# Altium schematic sheet Sheet1.SchDoc
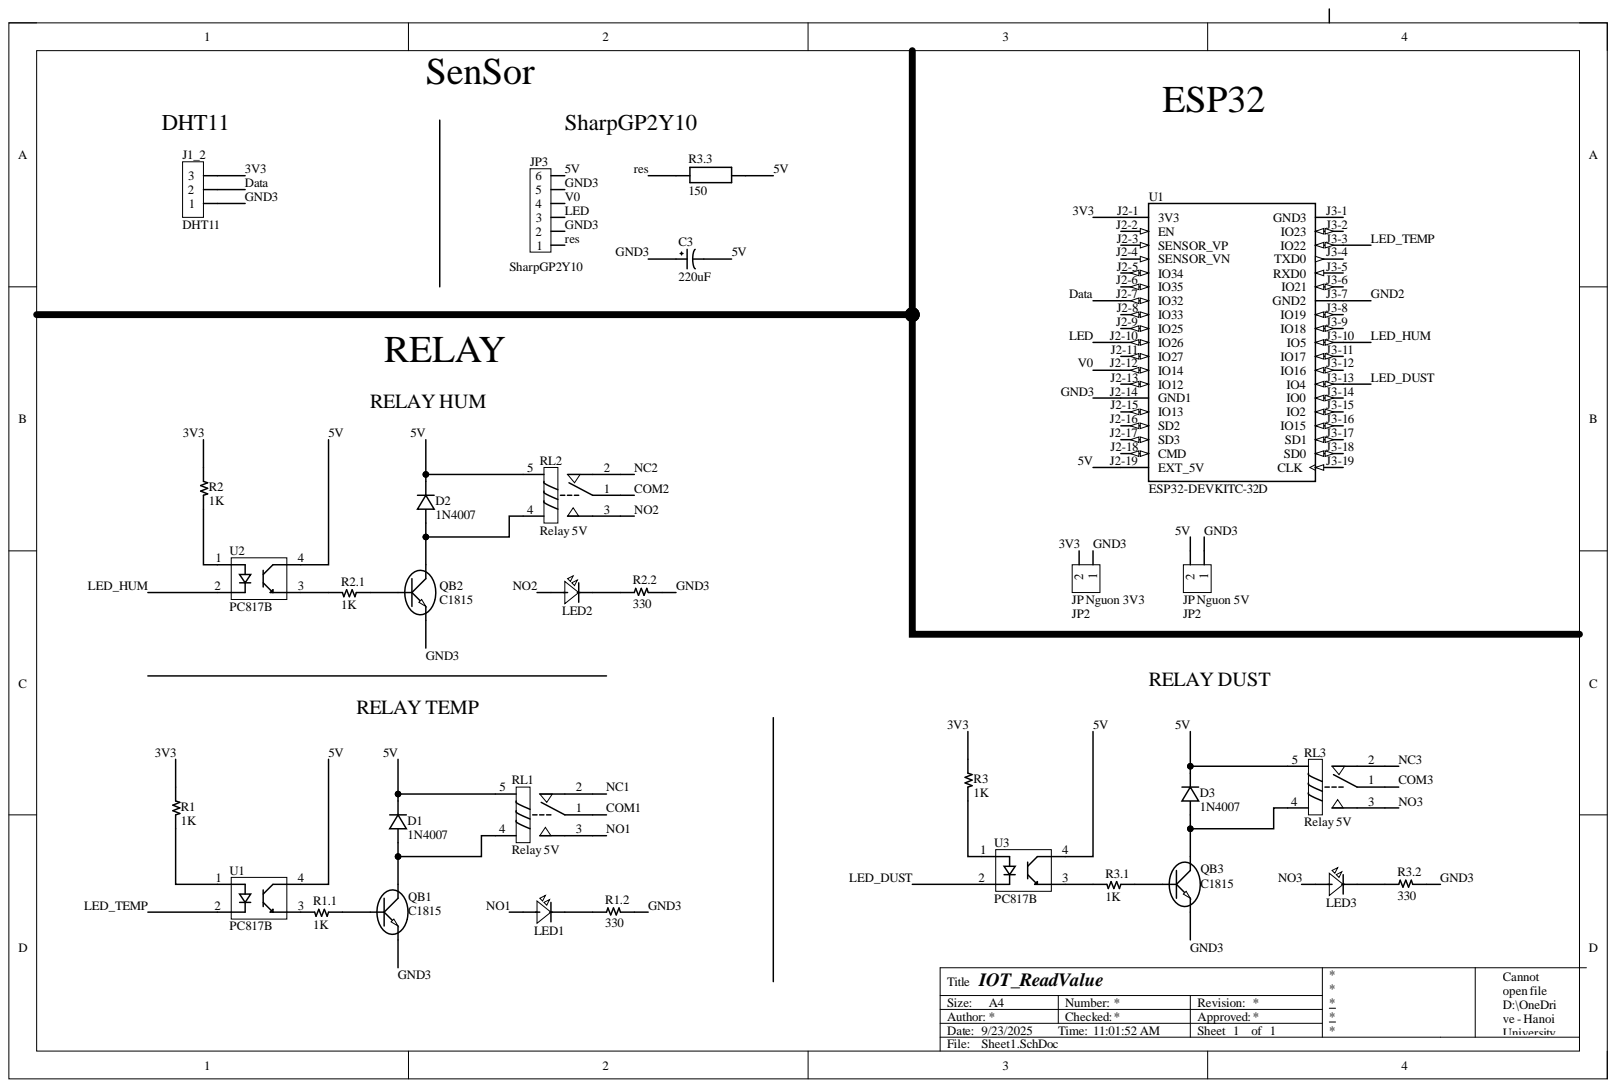
[diagram p0: Sheet1.SchDoc]

## Parts
C3  220uF  [CP1/2]
D1  1N4007  [D0.5A]
D2  1N4007  [D0.5A]
D3  1N4007  [D0.5A]
J1_2  DHT11  [JP3H]
JP3  SharpGP2Y10  [JP6]
JP_Nguon_3V3  JP2  [CON2]
JP_Nguon_5V  JP2  [CON2]
LED1  LED  [LED-5TRED]
LED2  LED  [LED-5TRED]
LED3  LED  [LED-5TRED]
QB1  C1815  [QTO-247]
QB2  C1815  [QTO-247]
QB3  C1815  [QTO-247]
R1  1K  [R 1/4W/300]
R1.1  1K  [R 1/4W/300]
R1.2  330  [R 1/4W/300]
R2  1K  [R 1/4W/300]
R2.1  1K  [R 1/4W/300]
R2.2  330  [R 1/4W/300]
R3  1K  [R 1/4W/300]
R3.1  1K  [R 1/4W/300]
R3.2  330  [R 1/4W/300]
R3.3  150  [Res_15mm]
RL1  Relay 5V  [RL_SPDT_10A]
RL2  Relay 5V  [RL_SPDT_10A]
RL3  Relay 5V  [RL_SPDT_10A]
U1  PC817B  [DIP 4]
U1  ESP32-DEVKITC-32D  [MODULE_ESP32-DEVKITC-32D]
U2  PC817B  [DIP 4]
U3  PC817B  [DIP 4]

## Nets
3V3: J1_2.3 JP_Nguon_3V3.2 R1.1 R2.1 R3.1 U1.J2-1(3V3)
5V: C3.2 D1.2 D2.2 D3.2 JP3.6 JP_Nguon_5V.2 R3.3.2 RL1.5 RL2.5 RL3.5 U1.4 U1.J2-19(EXT_5V) U2.4 U3.4
COM1: RL1.1
COM2: RL2.1
COM3: RL3.1
Data: J1_2.2 U1.J2-7(IO32)
GND2: U1.J3-7(GND2)
GND3: C3.1 J1_2.1 JP3.2 JP3.5 JP_Nguon_3V3.1 JP_Nguon_5V.1 QB1.3 QB2.3 QB3.3 R1.2.2 R2.2.2 R3.2.2 U1.J2-14(GND1)
LED: JP3.3 U1.J2-10(IO26)
LED_DUST: U1.J3-13(IO4) U3.2
LED_HUM: U1.J3-10(IO5) U2.2
LED_TEMP: U1.2 U1.J3-3(IO22)
NC1: RL1.2
NC2: RL2.2
NC3: RL3.2
NO1: LED1.1 RL1.3
NO2: LED2.1 RL2.3
NO3: LED3.1 RL3.3
res: JP3.1 R3.3.1
V0: JP3.4 U1.J2-12(IO14)
NetD1_1: D1.1 QB1.2 RL1.4
NetD2_1: D2.1 QB2.2 RL2.4
NetD3_1: D3.1 QB3.2 RL3.4
NetLED1_2: LED1.2 R1.2.1
NetLED2_2: LED2.2 R2.2.1
NetLED3_2: LED3.2 R3.2.1
NetQB1_1: QB1.1 R1.1.1
NetQB2_1: QB2.1 R2.1.1
NetQB3_1: QB3.1 R3.1.1
NetR1.1_2: R1.1.2 U1.3
NetR1_2: R1.2 U1.1
NetR2.1_2: R2.1.2 U2.3
NetR2_2: R2.2 U2.1
NetR3.1_2: R3.1.2 U3.3
NetR3_2: R3.2 U3.1

Not connected: U1 J2-2(EN) J2-3(SENSOR_VP) J2-4(SENSOR_VN) J2-5(IO34) J2-6(IO35) J2-8(IO33) J2-9(IO25) J2-11(IO27) J2-13(IO12) J2-15(IO13) J2-16(SD2) J2-17(SD3) J2-18(CMD) J3-1(GND3) J3-2(IO23) J3-4(TXD0) J3-5(RXD0) J3-6(IO21) J3-8(IO19) J3-9(IO18) J3-11(IO17) J3-12(IO16) J3-14(IO0) J3-15(IO2) J3-16(IO15) J3-17(SD1) J3-18(SD0) J3-19(CLK)

## Library data of the parts
C3 = CP1: Cap P  0,1uF < 22uF , 16 - 50V; Description 1: 10 => 220uF/6,3V/10V - 10uF => 100uF/16V; Description 2: 0,1uF => 33uF/50V - 10uF => 22uF/63V; Description 3: 0,47uF => 10uF/100V; Value: 10uF/50V; Component Kind: Standard; Library Name: SCH_Lib_Devzone.SchLib
D1-D3 = D1A: Diode 1A; Component Kind: Standard; Library Name: SCH_Lib_Devzone.SchLib; Value: 1N4007
J1_2 = JP3: Jumper 3; Value: J3; Component Kind: Standard; Library Name: SCH_Lib_Devzone.SchLib
JP3 = JP6: JP6 PIN; Value: JP7; Component Kind: Standard; Library Name: SCH_Lib_Devzone.SchLib
JP_Nguon_3V3 JP_Nguon_5V = Con2: Connector 2; Component Kind: Standard; Library Name: SCH_Lib_Devzone.SchLib; Value: Connector 2
LED1-LED3 = LED: LED Single colour Green and Red; Value: LED 3 ly Single Colour; Component Kind: Standard; Library Name: SCH_Lib_Devzone.SchLib
QB1-QB3 = D718: Transistor Power Supply; Value: D718; Component Kind: Standard; Library Name: SCH_Lib_Devzone.SchLib
R1 R1.1 R1.2 R2 R2.1 R2.2 R3 R3.1 R3.2 = Resistor: Component Kind: Standard; Description: Resistor; Library Name: chipfc_libs.SchLib
R3.3 = R1W: Resistor 1W; Value: R1W - 100; Component Kind: Standard; Library Name: SCH_Lib_Devzone.SchLib
RL1-RL3 = Relay 1: Relay SPDT,10A - 12VDC 5 Pin; Value: Relay SPDT, 10A, 12V; Component Kind: Standard; Library Name: SCH_Lib_Devzone.SchLib
U1-U3 = Opto PC817B: Component Kind: Standard; Library Name: chipfc_libs.SchLib
U1 = ESP32-DEVKITC-32D: WiFi Development Tools (802.11) ESP32 General Development Kit, ESP32-WROOM-32D on the board; MF: Espressif Systems; Check_prices: https://www.snapeda.com/parts/ESP32-DEVKITC-32D/Espressif+Systems/view-part/?ref=eda; Component Kind: Standard; Library Name: ESP32-DEVKITC-32D.IntLib; MP: ESP32-DEVKITC-32D; Package: None; SnapEDA_Link: https://www.snapeda.com/parts/ESP32-DEVKITC-32D/Espressif+Systems/view-part/?ref=snap
